# Altium schematic sheet Schematic_Sheet1.SchDoc(Schematic_Sheet1)
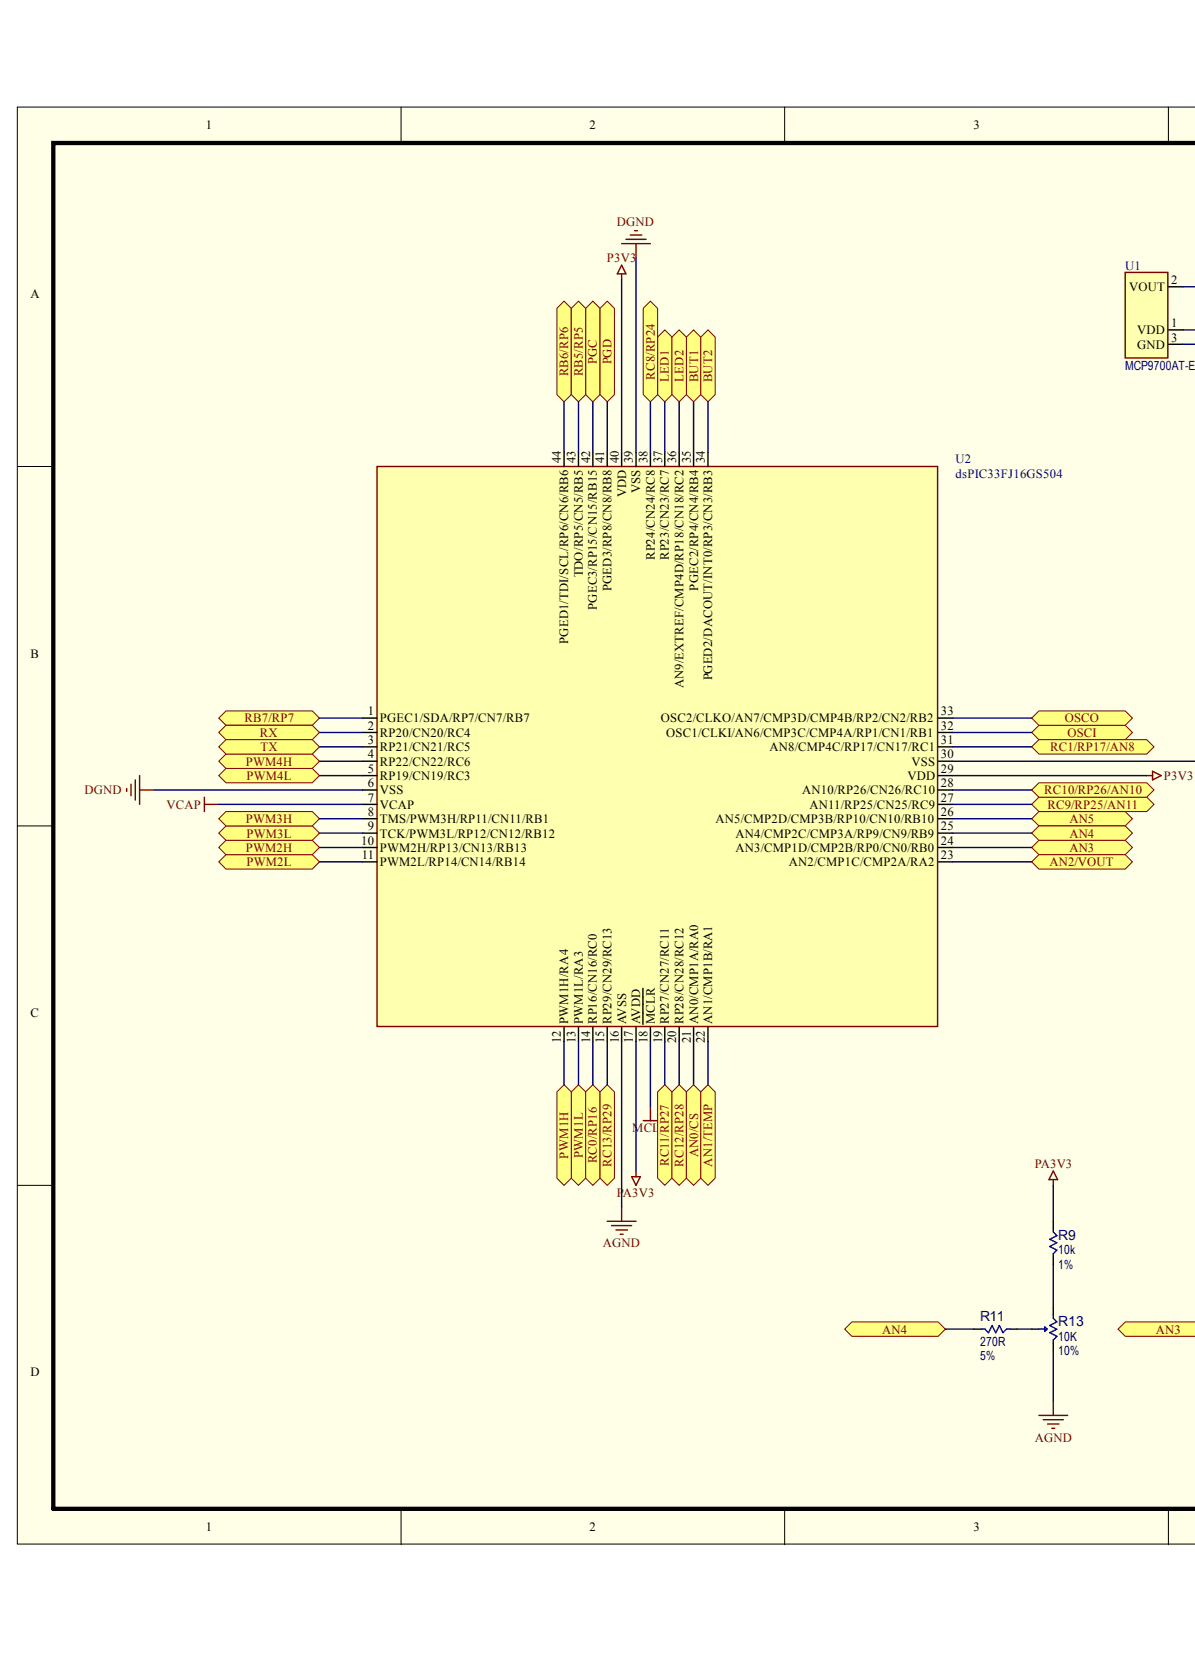
[diagram p0: Schematic_Sheet1.SchDoc, left]
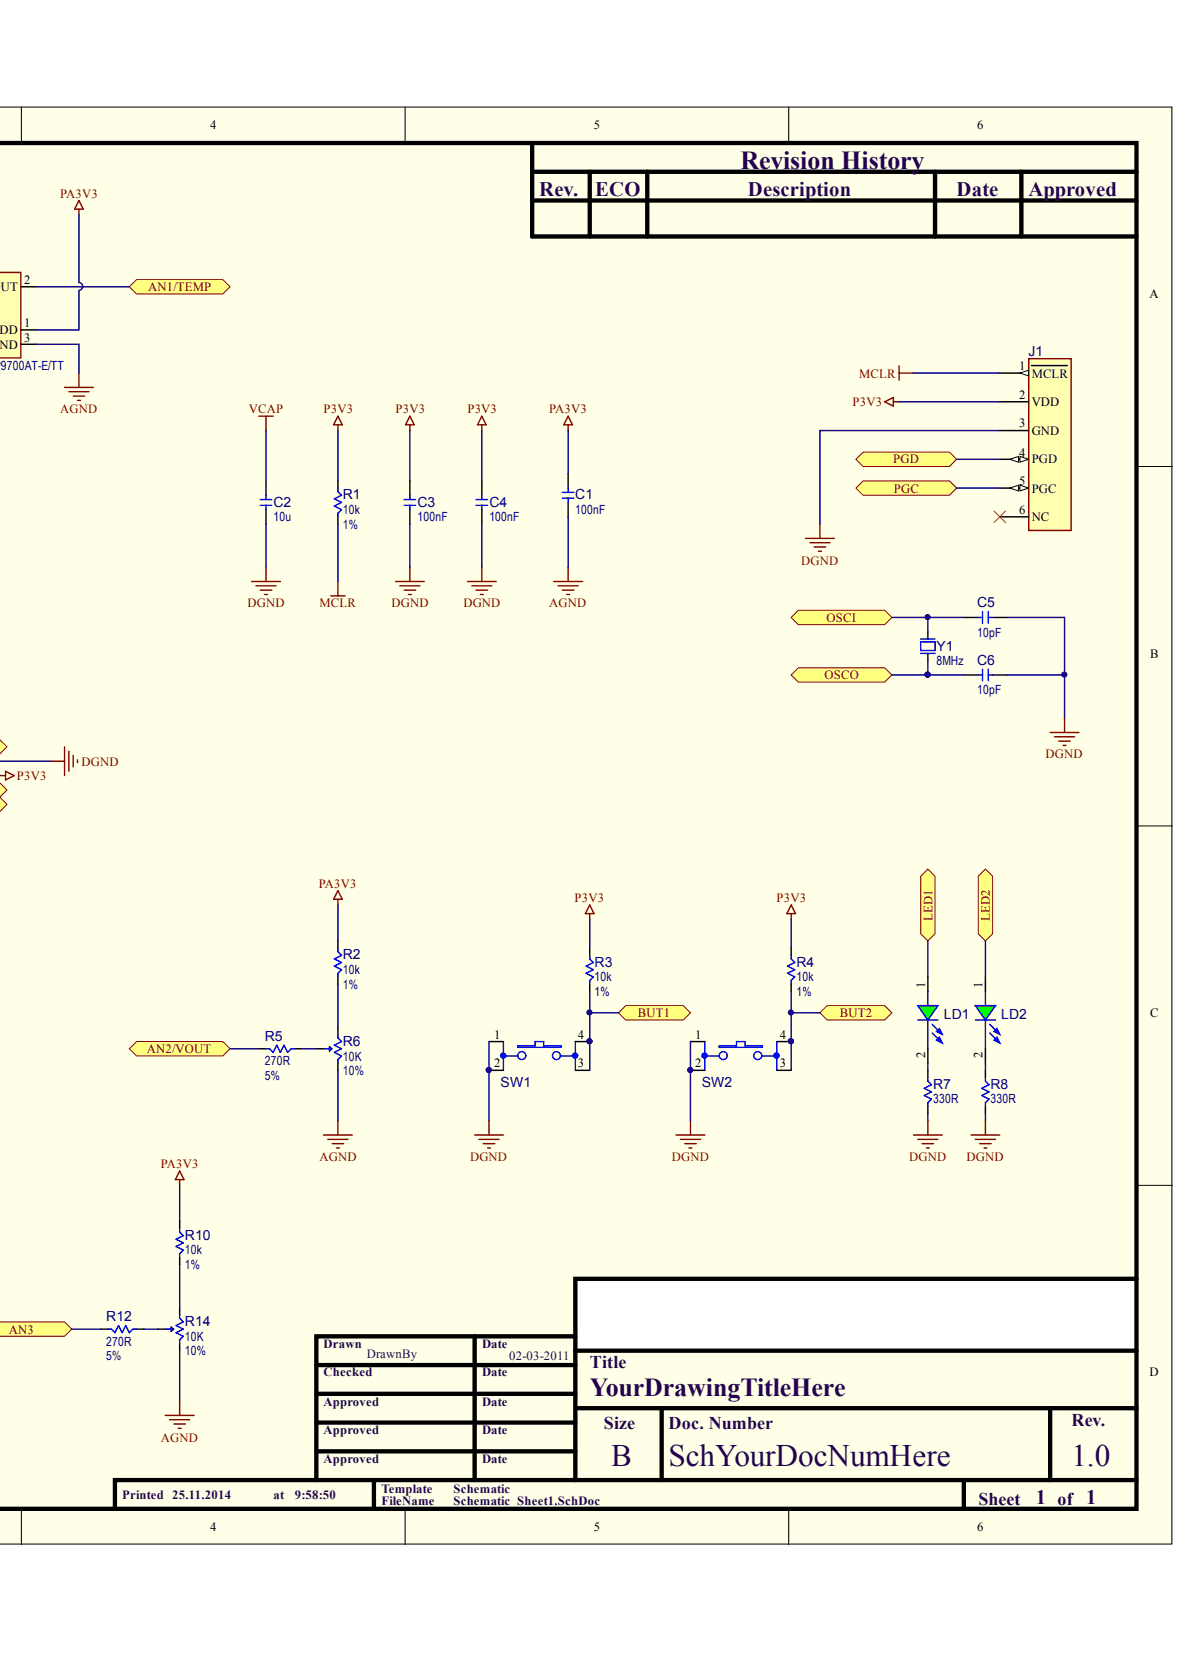
[diagram p1: Schematic_Sheet1.SchDoc, right]

## Parts
C1  value 100nF  (hidden comment: 06035C104JAT2A)
C2  value 10u  (hidden comment: 08056C106KAT2A)
C3  value 100nF  (hidden comment: 06035C104JAT2A)
C4  value 100nF  (hidden comment: 06035C104JAT2A)
C5  value 10pF  (hidden comment: MC0603N100D500CT)
C6  value 10pF  (hidden comment: MC0603N100D500CT)
J1  CON6_PK3_ICSP  (value not drawn)
LD1  LED_GREEN_0603  (value not drawn)
LD2  LED_GREEN_0603  (value not drawn)
R1  value 10k  Tolerance: 1%  (hidden comment: MCMR06X1002FTL)
R2  value 10k  Tolerance: 1%  (hidden comment: MCMR06X1002FTL)
R3  value 10k  Tolerance: 1%  (hidden comment: MCMR06X1002FTL)
R4  value 10k  Tolerance: 1%  (hidden comment: MCMR06X1002FTL)
R5  value 270R  Tolerance: 5%  (hidden comment: ERJ3GEYJ271V)
R6  value 10K  Tolerance: 10%  (hidden comment: 3386F-1-103TLF)
R7  value 330R  (hidden comment: MCMR06X3300FTL)
R8  value 330R  (hidden comment: MCMR06X3300FTL)
R9  value 10k  Tolerance: 1%  (hidden comment: MCMR06X1002FTL)
R10  value 10k  Tolerance: 1%  (hidden comment: MCMR06X1002FTL)
R11  value 270R  Tolerance: 5%  (hidden comment: ERJ3GEYJ271V)
R12  value 270R  Tolerance: 5%  (hidden comment: ERJ3GEYJ271V)
R13  value 10K  Tolerance: 10%  (hidden comment: 3386F-1-103TLF)
R14  value 10K  Tolerance: 10%  (hidden comment: 3386F-1-103TLF)
SW1  Switch 4 Pin  (value not drawn)
SW2  Switch 4 Pin  (value not drawn)
U1  MCP9700AT-E/TT
U2  dsPIC33FJ16GS504
Y1  value 8MHz  (hidden comment: OSC_SMD_8MHz)

## Ports
AN0/CS, AN1/TEMP, AN2/VOUT, AN3, AN4, AN5, BUT1, BUT2, LED1, LED2, OSCI, OSCO, PGC, PGD, PWM1H, PWM1L, PWM2H, PWM2L, PWM3H, PWM3L, PWM4H, PWM4L, RB5/RP5, RB6/RP6, RB7/RP7, RC0/RP16, RC1/RP17/AN8, RC8/RP24, RC9/RP25/AN11, RC10/RP26/AN10, RC11/RP27, RC12/RP28, RC13/RP29, RX, TX

## Nets
AGND: C1.1 R6.1 R13.1 R14.1 U1.3 U2.16(AVSS)
DGND: C2.1 C3.1 C4.1 C5.2 C6.2 J1.3(GND) R7.1 R8.1 SW1.1 SW1.2 SW2.1 SW2.2 U2.6(VSS) U2.30(VSS) U2.39(VSS)
MCLR: J1.1(MCLR) R1.1 U2.18(MCLR)
P3V3: C3.2 C4.2 J1.2(VDD) R1.2 R3.2 R4.2 U2.29(VDD) U2.40(VDD)
PA3V3: C1.2 R2.2 R9.2 R10.2 U1.1 U2.17(AVDD)
VCAP: C2.2 U2.7(VCAP)
AN0/CS: U2.21(AN0/CMP1A/RA0) ; port AN0/CS
AN1/TEMP: U1.2 U2.22(AN1/CMP1B/RA1) ; port AN1/TEMP
AN2/VOUT: R5.1 U2.23(AN2/CMP1C/CMP2A/RA2) ; port AN2/VOUT
AN3: R12.1 U2.24(AN3/CMP1D/CMP2B/RP0/CN0/RB0) ; port AN3
AN4: R11.1 U2.25(AN4/CMP2C/CMP3A/RP9/CN9/RB9) ; port AN4
AN5: U2.26(AN5/CMP2D/CMP3B/RP10/CN10/RB10) ; port AN5
BUT1: R3.1 SW1.3 SW1.4 U2.35(PGEC2/RP4/CN4/RB4) ; port BUT1
BUT2: R4.1 SW2.3 SW2.4 U2.34(PGED2/DACOUT/INT0/RP3/CN3/RB3) ; port BUT2
LED1: LD1.1 U2.37(RP23/CN23/RC7) ; port LED1
LED2: LD2.1 U2.36(AN9/EXTREF/CMP4D/RP18/CN18/RC2) ; port LED2
OSCI: C5.1 U2.32(OSC1/CLKI/AN6/CMP3C/CMP4A/RP1/CN1/RB1) Y1.2 ; port OSCI
OSCO: C6.1 U2.33(OSC2/CLKO/AN7/CMP3D/CMP4B/RP2/CN2/RB2) Y1.1 ; port OSCO
PGC: J1.5(PGC) U2.42(PGEC3/RP15/CN15/RB15) ; port PGC
PGD: J1.4(PGD) U2.41(PGED3/RP8/CN8/RB8) ; port PGD
PWM1H: U2.12(PWM1H/RA4) ; port PWM1H
PWM1L: U2.13(PWM1L/RA3) ; port PWM1L
PWM2H: U2.10(PWM2H/RP13/CN13/RB13) ; port PWM2H
PWM2L: U2.11(PWM2L/RP14/CN14/RB14) ; port PWM2L
PWM3H: U2.8(TMS/PWM3H/RP11/CN11/RB1) ; port PWM3H
PWM3L: U2.9(TCK/PWM3L/RP12/CN12/RB12) ; port PWM3L
PWM4H: U2.4(RP22/CN22/RC6) ; port PWM4H
PWM4L: U2.5(RP19/CN19/RC3) ; port PWM4L
RB5/RP5: U2.43(TDO/RP5/CN5/RB5) ; port RB5/RP5
RB6/RP6: U2.44(PGED1/TDI/SCL/RP6/CN6/RB6) ; port RB6/RP6
RB7/RP7: U2.1(PGEC1/SDA/RP7/CN7/RB7) ; port RB7/RP7
RC0/RP16: U2.14(RP16/CN16/RC0) ; port RC0/RP16
RC1/RP17/AN8: U2.31(AN8/CMP4C/RP17/CN17/RC1) ; port RC1/RP17/AN8
RC8/RP24: U2.38(RP24/CN24/RC8) ; port RC8/RP24
RC9/RP25/AN11: U2.27(AN11/RP25/CN25/RC9) ; port RC9/RP25/AN11
RC10/RP26/AN10: U2.28(AN10/RP26/CN26/RC10) ; port RC10/RP26/AN10
RC11/RP27: U2.19(RP27/CN27/RC11) ; port RC11/RP27
RC12/RP28: U2.20(RP28/CN28/RC12) ; port RC12/RP28
RC13/RP29: U2.15(RP29/CN29/RC13) ; port RC13/RP29
RX: U2.2(RP20/CN20/RC4) ; port RX
TX: U2.3(RP21/CN21/RC5) ; port TX
NetLD1_2: LD1.2 R7.2
NetLD2_2: LD2.2 R8.2
NetR2_1: R2.1 R6.3
NetR5_2: R5.2 R6.2
NetR9_1: R9.1 R13.3
NetR10_1: R10.1 R14.3
NetR11_2: R11.2 R13.2
NetR12_2: R12.2 R14.2

Not connected: J1 6(NC)

## Library data of the parts
C1 C3 C4 = (no symbol name): Manufacturer: AVX; Type: SMD_0402; Type_Cap: Ceramic; Tolerance: 5%; Voltage: 50V; Dielectric: X7R; Supplier: Farnell; Supplier Part Number: 1740621; Manufacturer Part Number: 06035C104JAT2A
C2 = (no symbol name): Manufacturer: AVX; Type: SMD_0805; Type_Cap: Ceramic; Tolerance: 10%; Voltage: 6.3V; Dielectric: X7R; Supplier: Farnell; Supplier Part Number: 2366667; Manufacturer Part Number: 08056C106KAT2A
C5 C6 = (no symbol name): Manufacturer: MULTICOMP; Type: SMD_0603; Type_Cap: Ceramic; Tolerance: 5%; Voltage: 50V; Dielectric: C0G / NP0; Supplier: Farnell; Supplier Part Number: 1759053; Manufacturer Part Number: MC0603N100D500CT
LD1 LD2 = (no symbol name): Manufacturer: OSRAM; Manufacturer Part Number: LGQ971; Type: SMD_0603; Vf: 2.2V; If: 20mA; Color: Green; Supplier: Farnell; Supplier Part Number: 1226372
R1-R4 R9 R10 = (no symbol name): Manufacturer: MULTICOMP; Power: 100mW; Manufacturer Part Number: MCMR06X1002FTL; Type: SMD_0603; Supplier: Farnell; Supplier Part Number: 2073349
R5 R11 R12 = (no symbol name): Manufacturer: PANASONIC; Power: 100mW; Manufacturer Part Number: ERJ3GEYJ271V; Type: SMD_0603; Supplier: Farnell; Supplier Part Number: 2059585
R6 R13 R14 = (no symbol name): Manufacturer: Bourns; Unit price at 2500: 1.1625; Manufacturer Part Number: 3386F-1-103TLF; Type: THT; Supplier 1: Digi-Key; Supplier 1 Part Number: 3386F-103TLF-ND; Power: *; Temperature Range: *; Supplier 2: Farnell; Supplier 2 Part Number: 9354565; Created By: Iulian Busu
R7 R8 = (no symbol name): Manufacturer: MULTICOMP; Power: 125mW; Tolerance: 1%; Manufacturer Part Number: MCMR06X3300FTL; Type: SMD_0603; Supplier: Farnell; Supplier Part Number: 2073472
U1 = (no symbol name): Manufacturer: MICROCHIP; Manufacturer Part Number: MCP9700AT-E/TT; Supplier 1: Digi-Key; Supplier 1 Part Number: MCP9700AT-E/TTTR-ND; Supplier 2: Farnell; Supplier 2 Part Number: 1605577; Temperature Range: -40°C +125°C; Case: SOT-23-3; Type: SMD; Value: MCP9700AT-E/TT; Created By: Iulian Busu
U2 = (no symbol name): Manufacturer: Microchip; Manufacturer Part Number: DSPIC33FJ16GS504-50I/PT; Comments: 50 MHz; Type: MCU16; Supplier: Farnell; Supplier Part Number: 2406558; Value: dsPIC33FJ16GS504
Y1 = (no symbol name): Manufacturer: VISHAY DALE; Manufacturer Part Number: XT49M-8M; Tolerance: 30ppm; Load Capacitance: 10pF; Type: SMD; Supplier: Farnell; Supplier Part Number: 1611765
J1 SW1 SW2 = (no symbol name)
  J1: Manufacturer: *; Type: THT/Right Angle; Supplier: Farnell; Manufacturer Part Number: *; Supplier Part Number: *
